# Altium schematic sheet IO.SchDoc(IO)
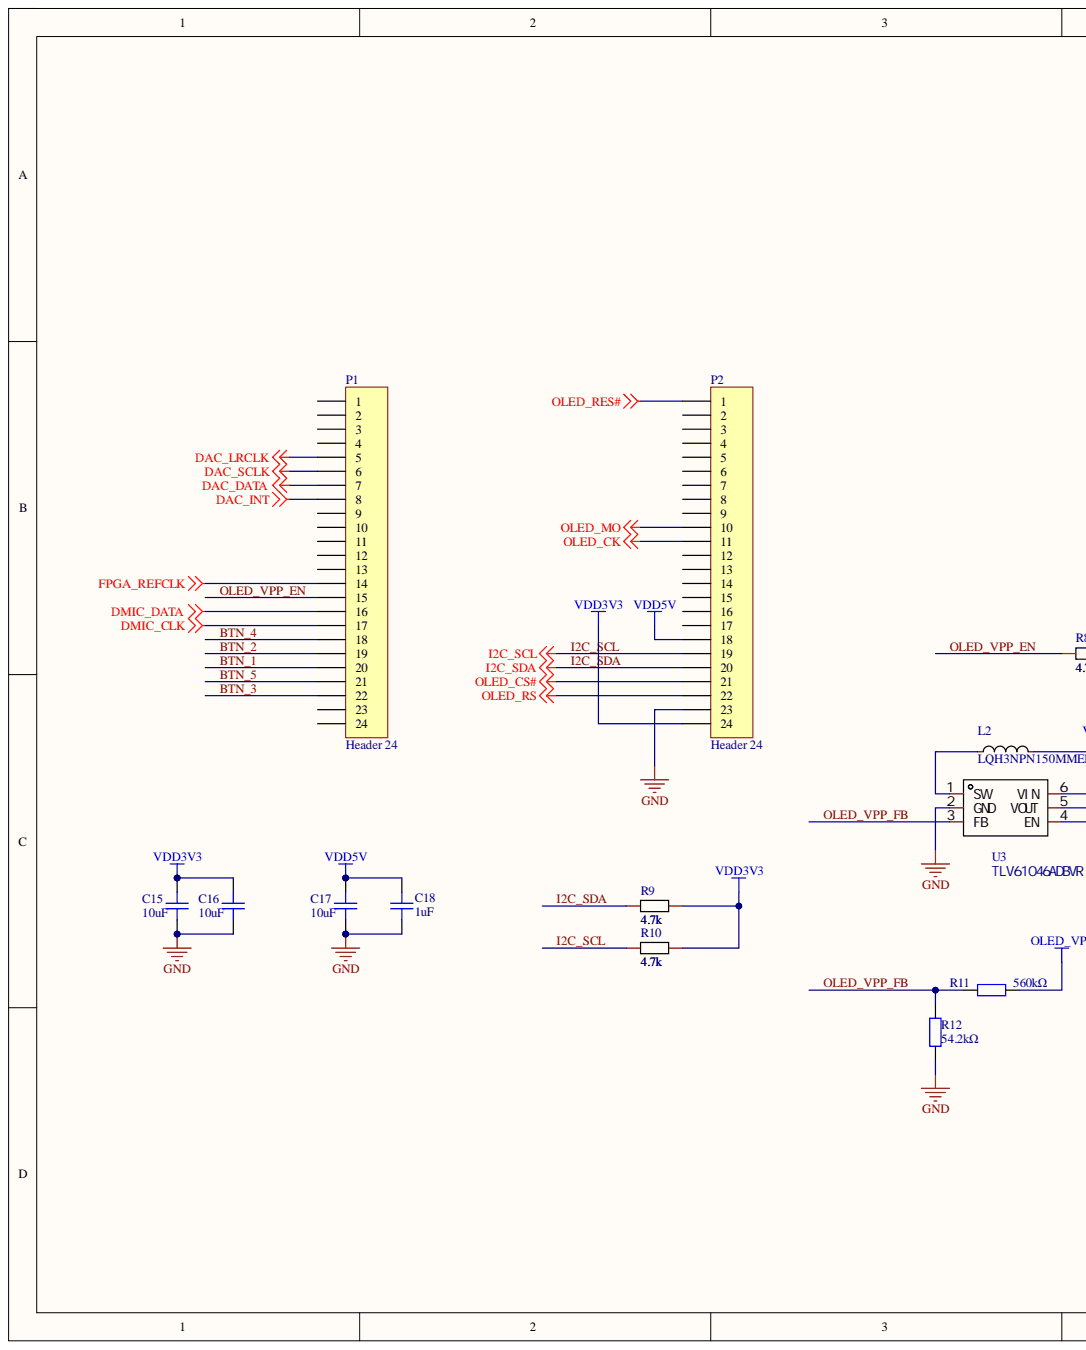
[diagram p0: IO.SchDoc, left]
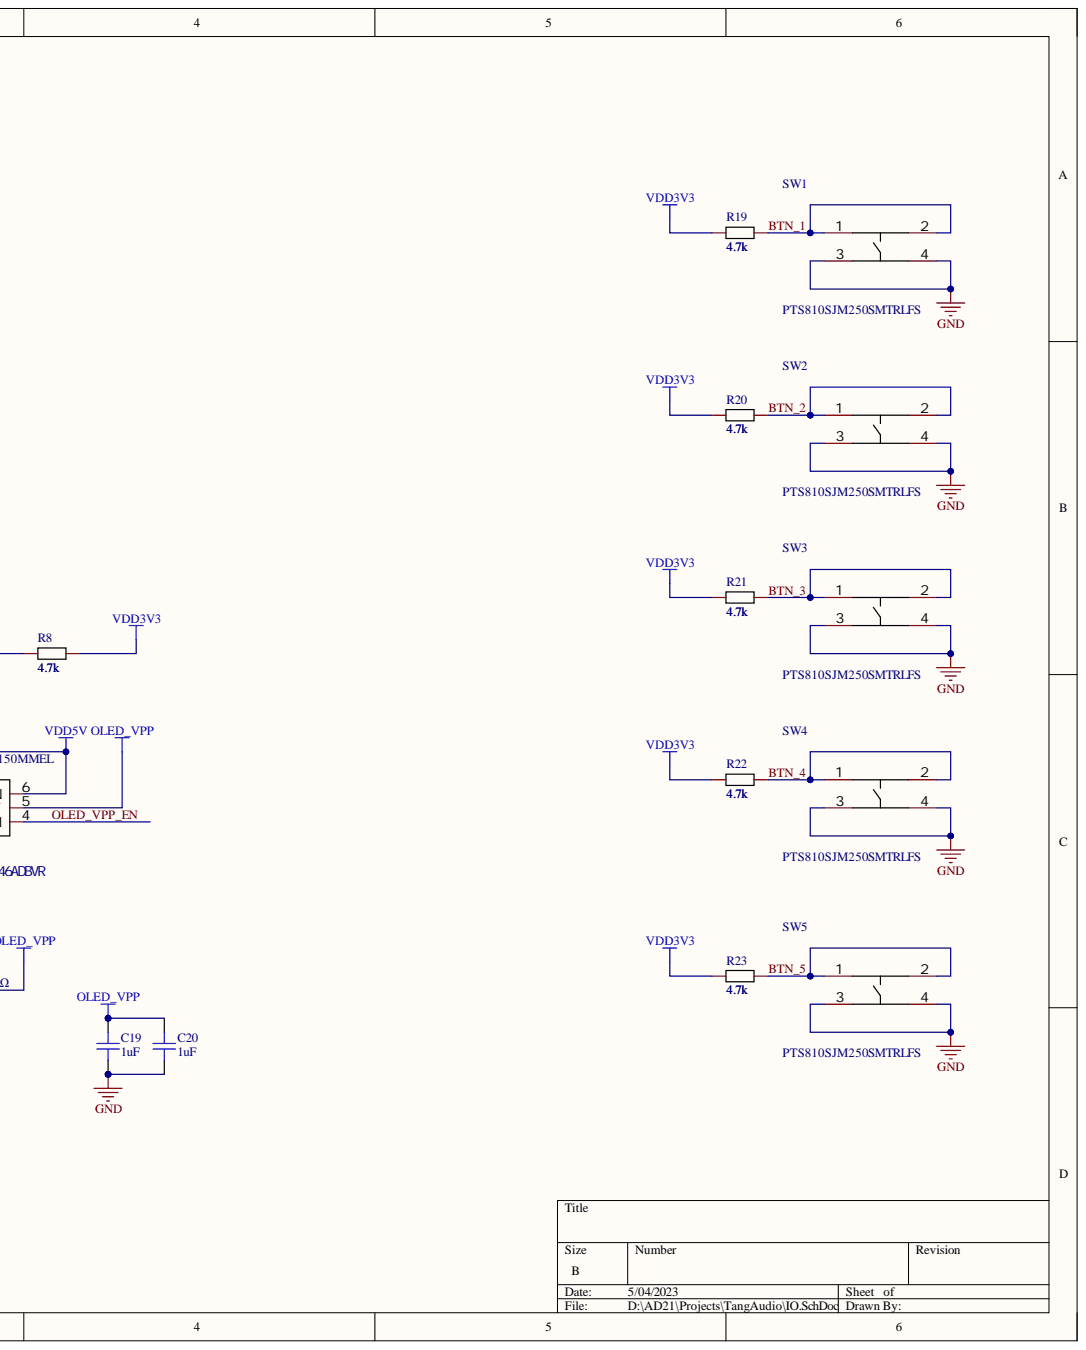
[diagram p1: IO.SchDoc, right]

## Parts
C15  value 10uF  [C0603]
C16  value 10uF  [C0603]
C17  value 10uF  [C0603]
C18  value 1uF  [C0603]
C19  value 1uF  [C0603]
C20  value 1uF  [C0603]
L2  LQH3NPN150MMEL  [IND-SMD_L3.0-W3.0_LQH3NPN]
P1  Header 24  [HDR1X24]
P2  Header 24  [HDR1X24]
R8  4.7k  [R0402]
R9  4.7k  [R0402]
R10  4.7k  [R0402]
R11  value 560kΩ  [R0402]
R12  value 54.2kΩ  [R0402]
R19  4.7k  [R0402]
R20  4.7k  [R0402]
R21  4.7k  [R0402]
R22  4.7k  [R0402]
R23  4.7k  [R0402]
SW1  PTS810SJM250SMTRLFS  [KEY-SMD_4P-L4.2-W3.2-P2.20-LS4.6]
SW2  PTS810SJM250SMTRLFS  [KEY-SMD_4P-L4.2-W3.2-P2.20-LS4.6]
SW3  PTS810SJM250SMTRLFS  [KEY-SMD_4P-L4.2-W3.2-P2.20-LS4.6]
SW4  PTS810SJM250SMTRLFS  [KEY-SMD_4P-L4.2-W3.2-P2.20-LS4.6]
SW5  PTS810SJM250SMTRLFS  [KEY-SMD_4P-L4.2-W3.2-P2.20-LS4.6]
U3  TLV61046ADBVR  [SOT-23-6_L2.9-W1.6-P0.95-LS2.8-BL]

## Nets
DAC_DATA: P1.7
DAC_INT: P1.8
DAC_LRCLK: P1.5
DAC_SCLK: P1.6
DMIC_CLK: P1.17
DMIC_DATA: P1.16
FPGA_REFCLK: P1.14
GND: C15.2 C16.2 C17.2 C18.2 C19.2 C20.2 P2.23 R12.1 SW1.3 SW1.4 SW2.3 SW2.4 SW3.3 SW3.4 SW4.3 SW4.4 SW5.3 SW5.4 U3.2(GND)
OLED_CK: P2.11
OLED_CS#: P2.21
OLED_MO: P2.10
OLED_RES#: P2.1
OLED_RS: P2.22
OLED_VPP: C19.1 C20.1 R11.2 U3.5(VOUT)
VDD3V3: C15.1 C16.1 P2.24 R8.2 R9.2 R10.2 R19.1 R20.1 R21.1 R22.1 R23.1
VDD5V: C17.1 C18.1 L2.2 P2.18 U3.6(VIN)
BTN_1: P1.20 R19.2 SW1.1 SW1.2
BTN_2: P1.19 R20.2 SW2.1 SW2.2
BTN_3: P1.22 R21.2 SW3.1 SW3.2
BTN_4: P1.18 R22.2 SW4.1 SW4.2
BTN_5: P1.21 R23.2 SW5.1 SW5.2
I2C_SCL: P2.19 R10.1
I2C_SDA: P2.20 R9.1
OLED_VPP_EN: P1.15 R8.1 U3.4(EN)
OLED_VPP_FB: R11.1 R12.2 U3.3(FB)
NetL2_1: L2.1 U3.1(SW)

Not connected: P1 1 2 3 4 9 10 11 12 13 23 24; P2 2 3 4 5 6 7 8 9 12 13 14 15 16 17

## Library data of the parts
C15-C17 = CL10A106KP8NNNC: Manufacturer: SAMSUNG(三星); Add into BOM: yes; Temperature Coefficient: X5R; Tolerance: ±10%; Voltage Rated: 10V; Component Kind: Standard; Convert to PCB: yes; Datasheet: https://atta.szlcsc.com/upload/public/pdf/source/20160103/1457707703378.pdf; Device: CL10A106KP8NNNC; JLCPCB Part Class: Basic Part; LCSC Part Name: 10uF ±10% 10V; Manufacturer Part: CL10A106KP8NNNC; PCB3D: C0603_L1.6-W0.8-H0.8; Supplier: LCSC; Supplier Footprint: 0603; Supplier Part: C19702; Symbol: CL10A106KP8NNNC
C18-C20 = CL05A105KA5NQNC: Manufacturer: SAMSUNG(三星); Add into BOM: yes; Temperature Coefficient: X5R; Tolerance: ±10%; Voltage Rated: 25V; Component Kind: Standard; Convert to PCB: yes; Datasheet: https://atta.szlcsc.com/upload/public/pdf/source/20160103/1457707735223.pdf; Device: CL05A105KA5NQNC; JLCPCB Part Class: Basic Part; LCSC Part Name: 1uF ±10% 25V; Manufacturer Part: CL05A105KA5NQNC; PCB3D: C0402_L1.0-W0.5-H0.5; Supplier: LCSC; Supplier Footprint: 0402; Supplier Part: C52923; Symbol: CL05A105KA5NQNC
L2 = LQH3NPN150MMEL: Manufacturer: muRata(村田); Add into BOM: yes; DC Resistance (DCR): 290mΩ; Frequency - Self Resonant: 15MHz; Name: 15uH; Rated Current: 620mA; Saturation Current (Isat): 660mA; Tolerance: ±20%; Value: 15uH; Component Kind: Standard; Convert to PCB: yes; Datasheet: https://atta.szlcsc.com/upload/public/pdf/source/20191022/C440248_094C93E028FCF0FA66FA57BC1ADCD3EF.pdf; Device: LQH3NPN150MMEL; JLCPCB Part Class: Extended Part; LCSC Part Name: 15uH ±20% 660mA 290mΩ; Manufacturer Part: LQH3NPN150MMEL; PCB3D: IND-SMD_L3.0-W3.0_LQH3NPN; Q @ Freq: -; Ratings: -; Supplier: LCSC; Supplier Footprint: SMD,3x3mm; Supplier Part: C668450; Symbol: LQH3NPN150MMEL
P1 P2 = Header 24: Header, 24-Pin; Component Kind: Standard; LatestRevisionDate: 17-Jul-2002; LatestRevisionNote: Re-released for DXP Platform.; Library Name: Miscellaneous Connectors.IntLib; Signal Integrity: Connector; Publisher: Altium Limited
R8-R10 R19-R23 = Res_0603: Add into BOM: yes; Symbol: 电阻; Component Kind: Standard; Convert to PCB: yes; Device: Res_0603; Name: 4.7k; PCB3D: R0603_L1.6-W0.8-H0.5; Supplier Footprint: 0603; Value: 4.7k
R11 R12 = 0603WAF200JT5E: Manufacturer: UNI-ROYAL(厚声); Add into BOM: yes; Overload Voltage (Max): 75V; Power(Watts): 1/10W; Temperature Coefficient: ±100ppm/; Tolerance: ±1%; Component Kind: Standard; Convert to PCB: yes; Datasheet: https://atta.szlcsc.com/upload/public/pdf/source/20200306/C422600_1E6D84923E4A46A82E41ADD87F860B5C.pdf; Device: 0603WAF200JT5E; JLCPCB Part Class: Basic Part; LCSC Part Name: 20Ω ±1%; Manufacturer Part: 0603WAF200JT5E; Operating Temperature Range: -55~+155; PCB3D: R0603_L1.6-W0.8-H0.8; Supplier: LCSC; Supplier Footprint: 0603; Supplier Part: C22950; Symbol: 0603WAF200JT5E; Type: 厚膜电阻
SW1-SW5 = PTS810SJM250SMTRLFS: Manufacturer: C&K; Actuator Style: 圆形按钮; Add into BOM: yes; Circuit: 单刀单掷; Contact Current: 50mA; Switch Height: 2.5mm; Switch Length: 4.2mm; Switch Width: 3.2mm; Voltage Rating (DC): 16V; Component Kind: Standard; Convert to PCB: yes; Datasheet: https://atta.szlcsc.com/upload/public/pdf/source/20180704/C116501_199B7AD0EF93D1F69D47FA53D559C299.pdf; Device: PTS810SJM250SMTRLFS; JLCPCB Part Class: Extended Part; LCSC Part Name: 4.2*3.2*2.5mm 立贴 轻触开关; Manufacturer Part: PTS810SJM250SMTRLFS; Mechanical Life: -; Mounting Style: 立贴; Name: PTS810SJM250SMTRLFS; PCB3D: KEY-SMD_4P-L4.2-W3.2-H2.5-LS4.6-P2.2; Supplier: LCSC; Supplier Footprint: SMD; Supplier Part: C116501; Symbol: PTS810SJM250SMTRLFS; With Lamp: -
U3 = TLV61046ADBVR: Manufacturer: TI(德州仪器); Add into BOM: yes; Function: 升压型; Input Voltage: 1.8V~5.5V; Number of Outputs: 1; Output Type: 可调; Output Voltage: 3.3V~28V; Switching Frequency: 1.05MHz; Topology: 升压式; Component Kind: Standard; Convert to PCB: yes; Device: TLV61046ADBVR; JLCPCB Part Class: Extended Part; LCSC Part Name: 升压型 输入1.8V~5.5V 输出3.3V~28V; Manufacturer Part: TLV61046ADBVR; Name: TLV61046ADBVR; Operating Temperature: -40℃~+85℃@(TA); Output Current (Max): -; PCB3D: SOT-23-6_L2.9-W1.6-H1.5-LS2.8-P0.95; Supplier: LCSC; Supplier Footprint: SOT-23-6; Supplier Part: C464815; Symbol: TLV61046ADBVR; Synchronous Rectification: -
